# Altium schematic sheet K66_Sheet3.SchDoc
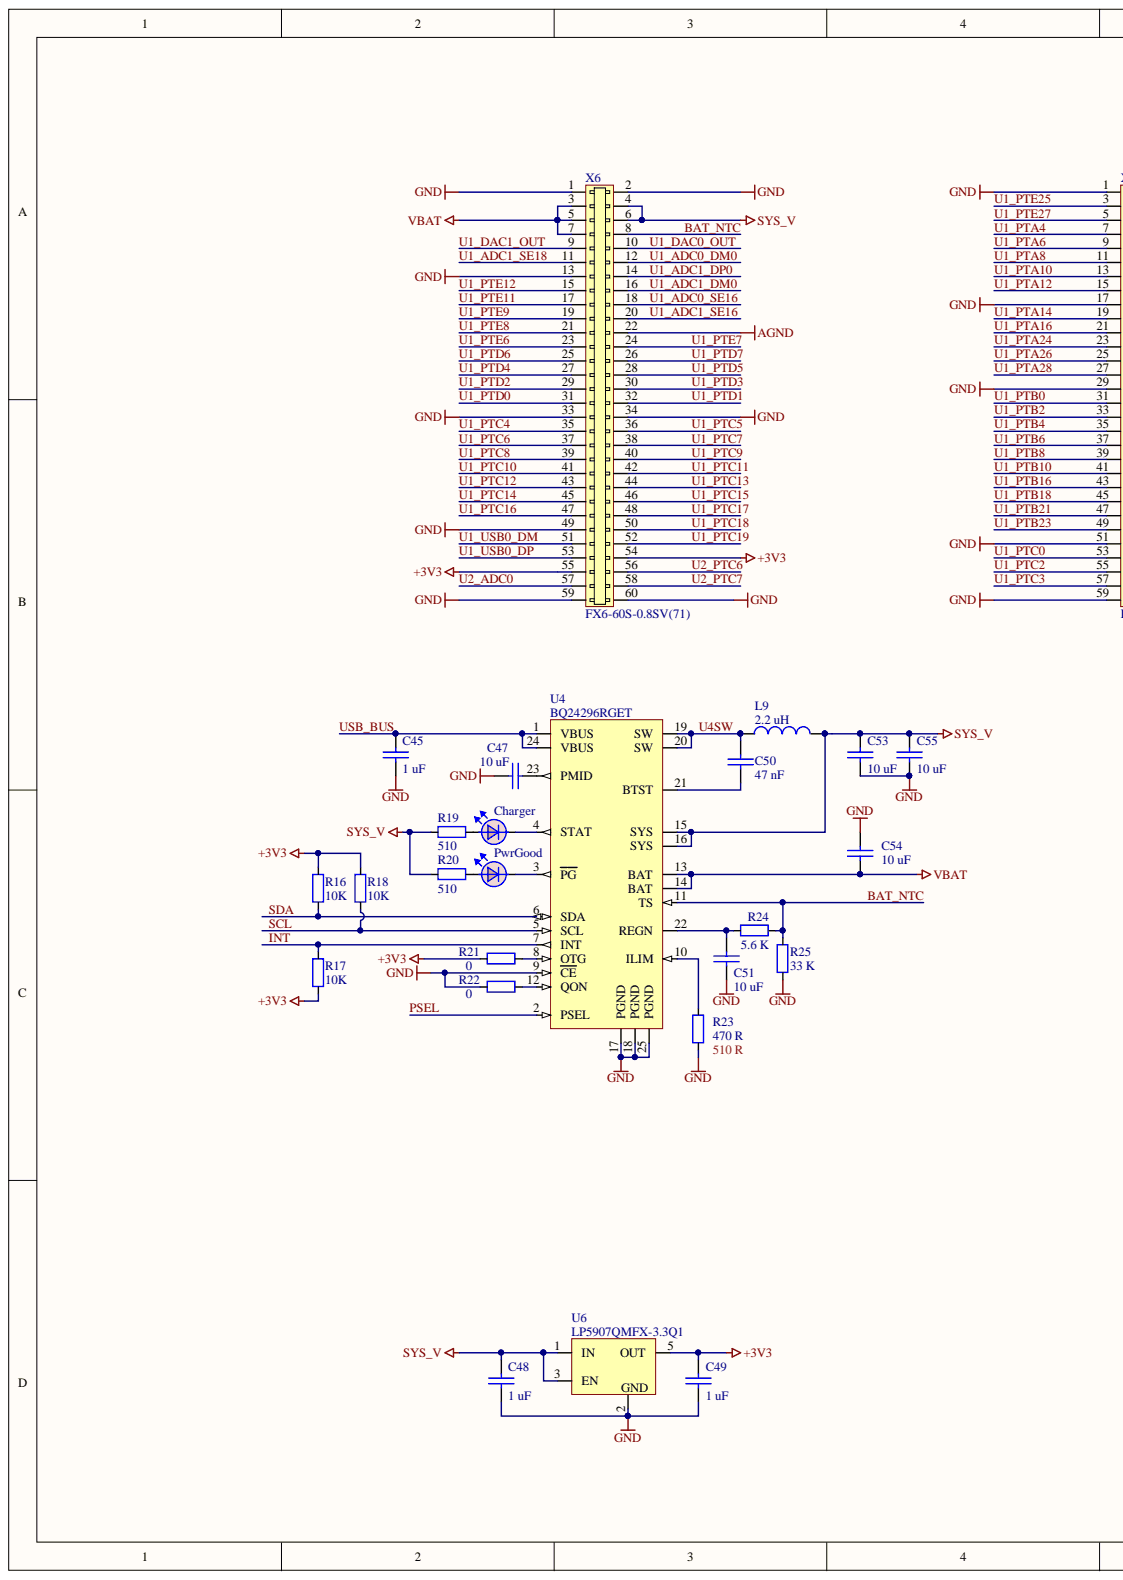
[diagram p0: K66_Sheet3.SchDoc, left]
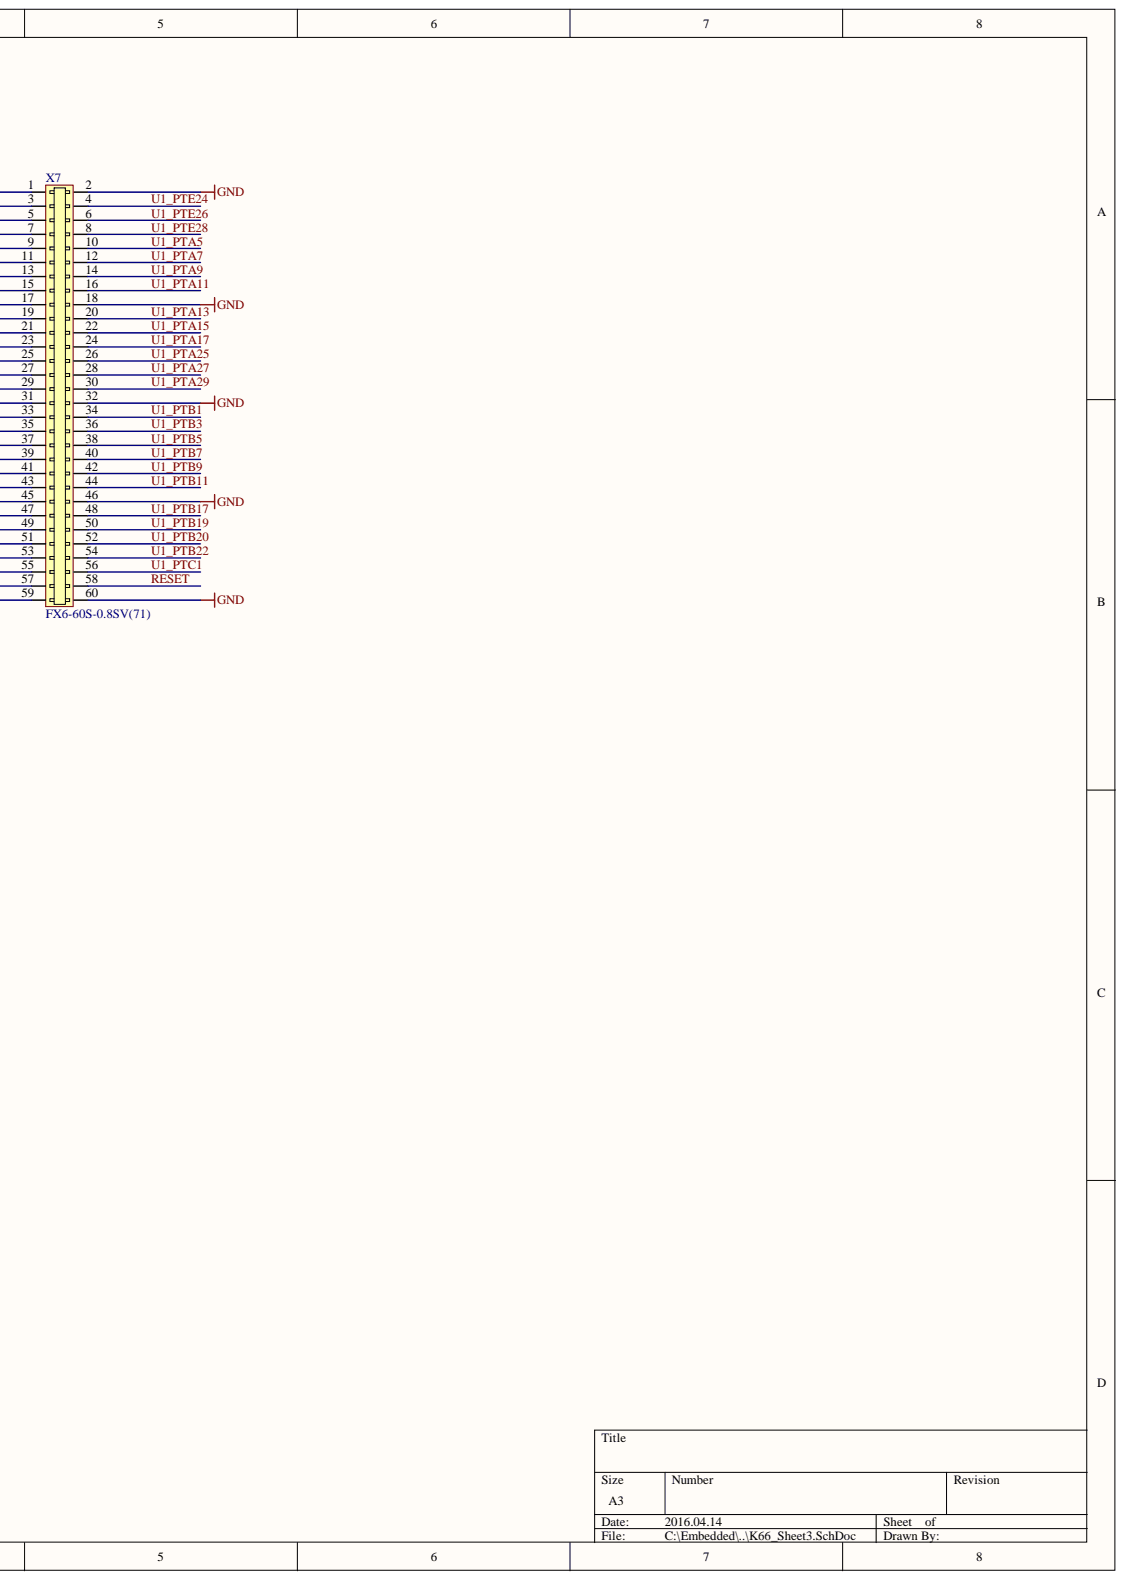
[diagram p1: K66_Sheet3.SchDoc, right]

## Parts
C45  value 1 uF  [1608[0603]]  (hidden comment: CL10A105KO8NNNC)
C47  value 10 uF  [1608[0603]]  (hidden comment: EMK107BBJ106MA-T)
C48  value 1 uF  [1608[0603]]  (hidden comment: CL10A105KO8NNNC)
C49  value 1 uF  [1608[0603]]  (hidden comment: CL10A105KO8NNNC)
C50  value 47 nF  [1005[0402]]  (hidden comment: C0402C473K4RACTU)
C51  value 10 uF  [1608[0603]]  (hidden comment: EMK107BBJ106MA-T)
C53  value 10 uF  [1608[0603]]  (hidden comment: EMK107BBJ106MA-T)
C54  value 10 uF  [1608[0603]]  (hidden comment: EMK107BBJ106MA-T)
C55  value 10 uF  [1608[0603]]  (hidden comment: EMK107BBJ106MA-T)
Charger  SML-211UTT86  [0805 LED]  (value not drawn)
L9  value 2.2 uH  [IHLP-2020BZ-11]  (hidden comment: IHLP2020BZER2R2M01)
PwrGood  SML-211UTT86  [0805 LED]  (value not drawn)
R16  value 10K  [0402]  (hidden comment: MCR01MZPJ103)
R17  value 10K  [0402]  (hidden comment: MCR01MZPJ103)
R18  value 10K  [0402]  (hidden comment: MCR01MZPJ103)
R19  value 510  [0603]  (hidden comment: RL0603E510-1)
R20  value 510  [0603]  (hidden comment: RL0603E510-1)
R21  value 0  [0402]  (hidden comment: MCR01MZPJ000)
R22  value 0  [0402]  (hidden comment: MCR01MZPJ000)
R23  value 470 R  [0603]  (hidden comment: RL0603E470-1)
R24  value 5.6 K  [0603]  (hidden comment: RL0603K005.6-1)
R25  value 33 K  [0603]  (hidden comment: RL0603K033-1)
U4  BQ24296RGET  [TI S-PVQFN-N24]
U6  LP5907QMFX-3.3Q1  [SOT23-5]
X6  FX6-60S-0.8SV(71)  [FX6-60S-0.8SV(71)]
X7  FX6-60S-0.8SV(71)  [FX6-60S-0.8SV(71)]

## Nets
+3V3: C49.1 R16.2 R17.1 R18.2 R21.1 U6.5(OUT) X6.54 X6.55
AGND: X6.22
GND: C45.2 C47.1 C48.2 C49.2 C51.2 C53.2 C54.1 C55.2 R22.1 R23.1 R25.1 U4.9(CE) U4.17(PGND) U4.18(PGND) U4.25(PGND) U6.2 X6.1 X6.2 X6.13 X6.33 X6.34 X6.49 X6.59 X6.60 X7.1 X7.2 X7.17 X7.18 X7.29 X7.32 X7.46 X7.51 X7.59 X7.60
SYS_V: C48.1 C53.1 C55.1 L9.2 R19.2 R20.2 U4.15(SYS) U4.16(SYS) U6.1(IN) U6.3(EN) X6.4 X6.6
VBAT: C54.2 U4.13(BAT) U4.14(BAT) X6.3 X6.5 X6.7
BAT_NTC: R24.1 R25.2 U4.11(TS) X6.8
INT: R17.2 U4.7(INT)
PSEL: U4.2(PSEL)
RESET: X7.58
SCL: R18.1 U4.5(SCL)
SDA: R16.1 U4.6(SDA)
U1_ADC0_DM0: X6.12
U1_ADC0_SE16: X6.18
U1_ADC1_DM0: X6.16
U1_ADC1_DP0: X6.14
U1_ADC1_SE16: X6.20
U1_ADC1_SE18: X6.11
U1_DAC0_OUT: X6.10
U1_DAC1_OUT: X6.9
U1_PTA4: X7.7
U1_PTA5: X7.10
U1_PTA6: X7.9
U1_PTA7: X7.12
U1_PTA8: X7.11
U1_PTA9: X7.14
U1_PTA10: X7.13
U1_PTA11: X7.16
U1_PTA12: X7.15
U1_PTA13: X7.20
U1_PTA14: X7.19
U1_PTA15: X7.22
U1_PTA16: X7.21
U1_PTA17: X7.24
U1_PTA24: X7.23
U1_PTA25: X7.26
U1_PTA26: X7.25
U1_PTA27: X7.28
U1_PTA28: X7.27
U1_PTA29: X7.30
U1_PTB0: X7.31
U1_PTB1: X7.34
U1_PTB2: X7.33
U1_PTB3: X7.36
U1_PTB4: X7.35
U1_PTB5: X7.38
U1_PTB6: X7.37
U1_PTB7: X7.40
U1_PTB8: X7.39
U1_PTB9: X7.42
U1_PTB10: X7.41
U1_PTB11: X7.44
U1_PTB16: X7.43
U1_PTB17: X7.48
U1_PTB18: X7.45
U1_PTB19: X7.50
U1_PTB20: X7.52
U1_PTB21: X7.47
U1_PTB22: X7.54
U1_PTB23: X7.49
U1_PTC0: X7.53
U1_PTC1: X7.56
U1_PTC2: X7.55
U1_PTC3: X7.57
U1_PTC4: X6.35
U1_PTC5: X6.36
U1_PTC6: X6.37
U1_PTC7: X6.38
U1_PTC8: X6.39
U1_PTC9: X6.40
U1_PTC10: X6.41
U1_PTC11: X6.42
U1_PTC12: X6.43
U1_PTC13: X6.44
U1_PTC14: X6.45
U1_PTC15: X6.46
U1_PTC16: X6.47
U1_PTC17: X6.48
U1_PTC18: X6.50
U1_PTC19: X6.52
U1_PTD0: X6.31
U1_PTD1: X6.32
U1_PTD2: X6.29
U1_PTD3: X6.30
U1_PTD4: X6.27
U1_PTD5: X6.28
U1_PTD6: X6.25
U1_PTD7: X6.26
U1_PTE6: X6.23
U1_PTE7: X6.24
U1_PTE8: X6.21
U1_PTE9: X6.19
U1_PTE11: X6.17
U1_PTE12: X6.15
U1_PTE24: X7.4
U1_PTE25: X7.3
U1_PTE26: X7.6
U1_PTE27: X7.5
U1_PTE28: X7.8
U1_USB0_DM: X6.51
U1_USB0_DP: X6.53
U2_ADC0: X6.57
U2_PTC6: X6.56
U2_PTC7: X6.58
U4SW: C50.1 L9.1 U4.19(SW) U4.20(SW)
USB_BUS: C45.1 U4.1(VBUS) U4.24(VBUS)
NetC47_2: C47.2 U4.23(PMID)
NetC50_2: C50.2 U4.21(BTST)
NetC51_1: C51.1 R24.2 U4.22(REGN)
NetCharger_1: Charger.1 R19.1
NetCharger_2: Charger.2 U4.4(STAT)
NetPwrGood_1: PwrGood.1 R20.1
NetPwrGood_2: PwrGood.2 U4.3(PG)
NetR21_2: R21.2 U4.8(OTG)
NetR22_2: R22.2 U4.12(QON)
NetR23_2: R23.2 U4.10(ILIM)

## Library data of the parts
C45 C48 C49 = CL10A105KO8NNNC: 1.0 uF, 16V, 10%, X5R, 0603, -55..85 С; Manufacturer: Samsung; PartNum: 1276-1034-1-ND; Component Kind: Standard; Distributor: Digi-Key; PartName: CL10A105KO8NNNC; Tolerance: 10%; Library Name: ea_Capacitors.SCHLIB
C47 C51 C54 C55 = EMK107BBJ106MA-T: 10 uF, 16V, X5R, 20%, 0603, -55°C ~ 85°C; Manufacturer: Taiyo Yuden; PartNum: 587-3238-1-ND; Component Kind: Standard; Distributor: Digi-Key; PartName: EMK107BBJ106MA-T; Tolerance: 20%; Library Name: ea_Capacitors.SCHLIB
C50 = C0402C473K4RACTU: 47 nF, 16V, 10%, X7R, 0402, -55..+125 C; Manufacturer: Kemet; PartNum: 399-3519-1-ND; Component Kind: Standard; Distributor: Digi-Key; PartName: C0402C473K4RACTU; Tolerance: 10%; Library Name: ea_Capacitors.SCHLIB
C53 = EMK107BBJ106MA-T: 10 uF, 16V, X5R, 20%, 0603, -55°C ~ 85°C; Manufacturer: Taiyo Yuden; PartNum: 587-3238-1-ND; Value: 10 uF; Component Kind: Standard; Distributor: Digi-Key; PartName: EMK107BBJ106MA-T; Tolerance: 20%; Library Name: ea_Capacitors.SCHLIB
Charger PwrGood = SML-211UTT86: 0805 red LED, hibright, 2 mA, 1.8V, 130°; Manufacturer: Rohm; PartNum: 511-1292-1-ND; Component Kind: Standard; Distributor: Digi-Key; PartName: SML-211UTT86; Price: 0.34$; Value: Red; Library Name: ea_Indicators.SCHLIB
L9 = IHLP2020BZER2R2M01: 2.2 uH, 4.2 A, 50 mOhms, +-20%,-55.. +125 C; Manufacturer: Vishay Dale; PartNum: 541-1088-1-ND; Tolerance: ±20%; Component Kind: Standard; Distributor: Digi-Key; PartName: IHLP2020BZER2R2M01; Library Name: ea_Inductors.SCHLIB
R16-R18 = MCR01MZPJ103: 10 K, 0402, Thick Film, -55..+155; Manufacturer: Rohm Semiconductor; PartNum: RHM10KJTR-ND; Tolerance: 5%; Component Kind: Standard; Distributor: Digi-Key; PartName: MCR01MZPJ103; Price: 0.1$; Library Name: ea_Resistors.SCHLIB
R19 R20 = RL0603E510-1: 510 R, 1%, 0603, SMD Resistor, Taped; Manufacturer: Vishay; Component Kind: Standard; Distributor: Schukat; PartName: RL0603E510-1; PartNum: RL0603E510-1; Price: 0.002 Euro; Tolerance: 1%; Library Name: ea_Resistors.SCHLIB
R21 R22 = MCR01MZPJ000: 0 Ohm, 0402, Thick Film, -55..+155; Manufacturer: Rohm Semiconductor; PartNum: RHM0.0JCT-ND; Component Kind: Standard; Distributor: Digi-Key; PartName: MCR01MZPJ000; Price: 0.1$; Library Name: ea_Resistors.SCHLIB
R23 = RL0603E470-1: 470 R, 1%, 0603, SMD Resistor, Taped; Manufacturer: Vishay; Component Kind: Standard; Distributor: Schukat; PartName: RL0603E470-1; PartNum: RL0603E470-1; Price: 0.002 Euro; Tolerance: 1%; Library Name: ea_Resistors.SCHLIB
R24 = RL0603K005.6-1: 5.6 K, 1%, 0603, SMD Resistor, Taped; Manufacturer: Vishay; Component Kind: Standard; Distributor: Schukat; PartName: RL0603K005.6-1; PartNum: RL0603K005.6-1; Price: 0.002 Euro; Tolerance: 1%; Library Name: ea_Resistors.SCHLIB
R25 = RL0603K033-1: 33 K, 1%, 0603, SMD Resistor, Taped; Manufacturer: Vishay; Component Kind: Standard; Distributor: Schukat; PartName: RL0603K033-1; PartNum: RL0603K033-1; Price: 0.002 Euro; Tolerance: 1%; Library Name: ea_Resistors.SCHLIB
U4 = BQ24296RGET: IC LI+ CHARGER PWR MGMT 24VQFN; Manufacturer: TI; PartNum: 296-39592-1-ND; Component Kind: Standard; Distributor: Digi-Key; PartName: BQ24296RGET; Library Name: ea_USB.SCHLIB
U6 = LP5907QMFX-3.3Q1: LDO 3.3 V, 250 mA, Vin < 5.5V, Iq < 12uA, -40..+125 C, SOT23-5; Manufacturer: Texas Instruments; PartNum: 296-40365-1-ND; Component Kind: Standard; Distributor: Digi-Key; PartName: LP5907QMFX-3.3Q1; Library Name: ea_IC_Power_Reg_Ref_Sw.SCHLIB
X6 X7 = FX6-60S-0.8SV(71): RECEPT 60POS .8MM W/BOSS; Manufacturer: Hirose Electric Co Ltd; PartNum: H10806-ND; Component Kind: Standard; Distributor: DigiKey; PartName: FX6-60S-0.8SV(71); Price: 3.7$; Library Name: ea_Connectors.SCHLIB
